# Altium schematic sheet PIN(5~6).SchDoc(PIN(5~6))
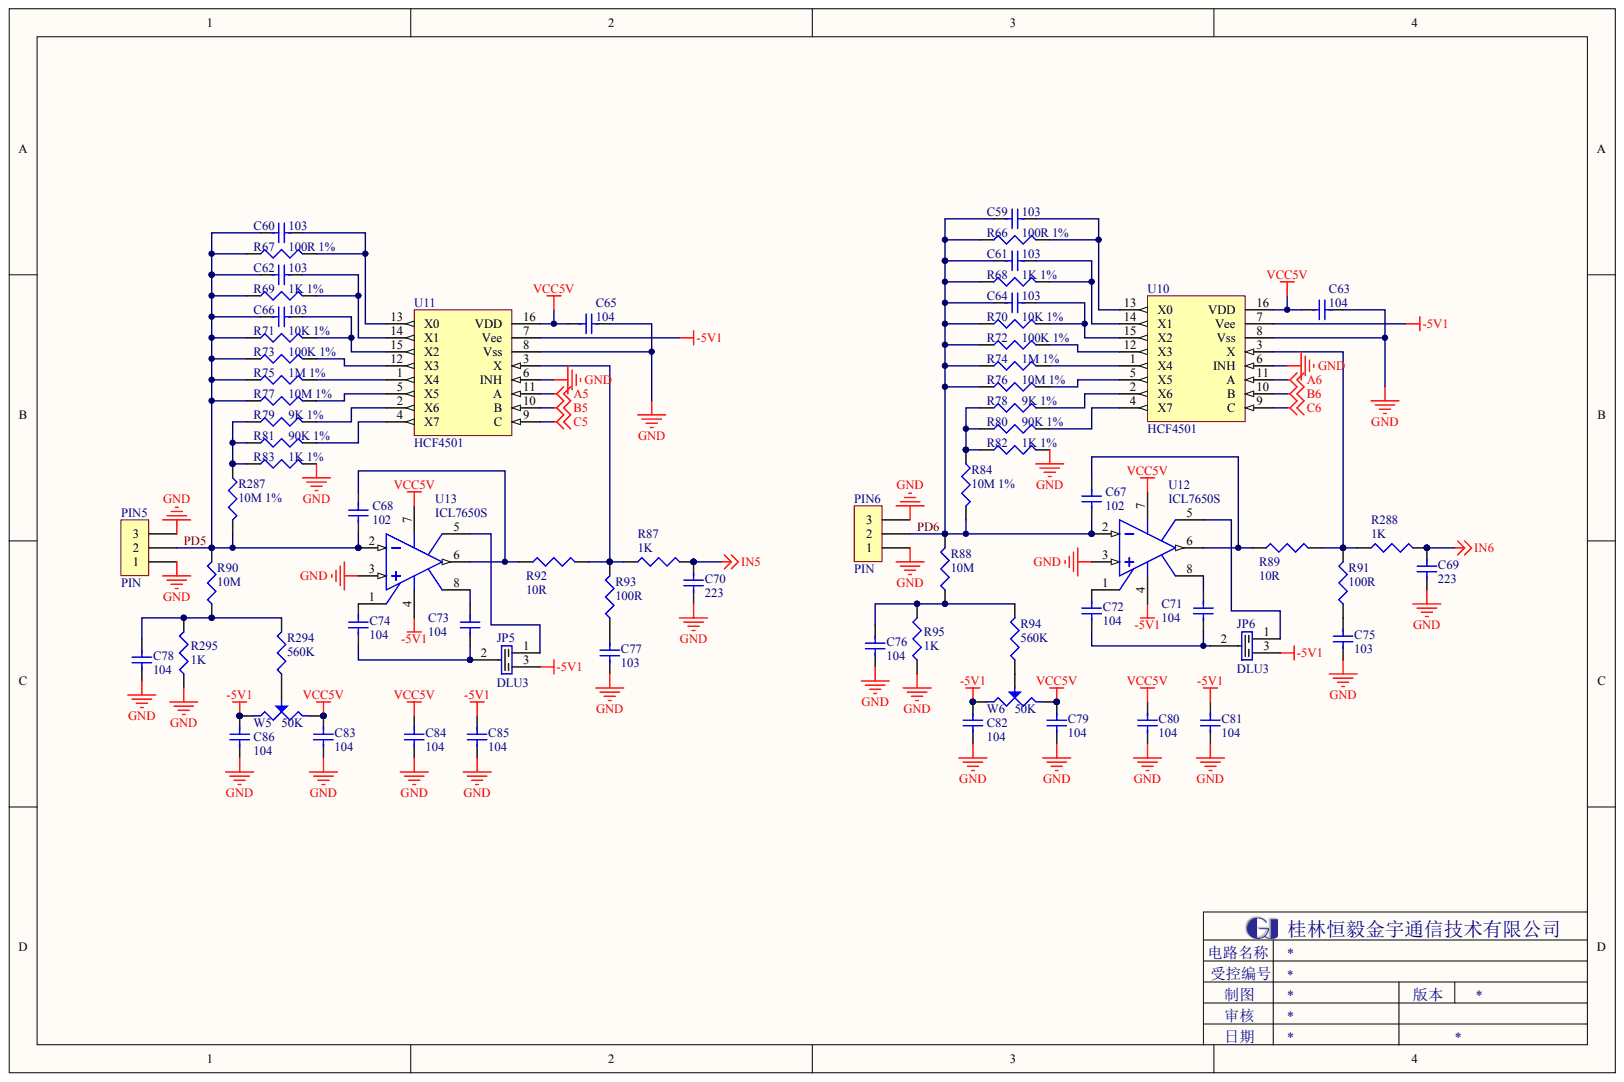
[diagram p0: PIN]

## Parts
C59  103
C60  103
C61  103
C62  103
C63  104
C64  103
C65  104
C66  103
C67  102
C68  102
C69  223
C70  223
C71  104
C72  104
C73  104
C74  104
C75  103
C76  104
C77  103
C78  104
C79  104
C80  104
C81  104
C82  104
C83  104
C84  104
C85  104
C86  104
JP5  DLU3
JP6  DLU3
PIN5  PIN
PIN6  PIN
R66  100R 1%
R67  100R 1%
R68  1K 1%
R69  1K 1%
R70  10K 1%
R71  10K 1%
R72  100K 1%
R73  100K 1%
R74  1M 1%
R75  1M 1%
R76  10M 1%
R77  10M 1%
R78  9K 1%
R79  9K 1%
R80  90K 1%
R81  90K 1%
R82  1K 1%
R83  1K 1%
R84  10M 1%
R87  1K
R88  10M
R89  10R
R90  10M
R91  100R
R92  10R
R93  100R
R94  560K
R95  1K
R287  10M 1%
R288  1K
R294  560K
R295  1K
U10  HCF4501
U11  HCF4501
U12  ICL7650S
U13  ICL7650S
W5  50K
W6  50K

## Nets
-5V1: C81.2 C82.1 C85.2 C86.1 JP5.3 JP6.3 U10.7(Vee) U11.7(Vee) U12.4 U13.4 W5.1 W6.1
A5: U11.11(A)
A6: U10.11(A)
B5: U11.10(B)
B6: U10.10(B)
C5: U11.9(C)
C6: U10.9(C)
GND: C63.2 C65.2 C69.1 C70.1 C75.1 C76.1 C77.1 C78.1 C79.1 C80.1 C81.1 C82.2 C83.1 C84.1 C85.1 C86.2 PIN5.1 PIN5.3 PIN6.1 PIN6.3 R82.2 R83.2 R95.1 R295.1 U10.6(INH) U10.8(Vss) U11.6(INH) U11.8(Vss) U12.3 U13.3
IN5: C70.2 R87.2
IN6: C69.2 R288.2
VCC5V: C63.1 C65.1 C79.2 C80.2 C83.2 C84.2 U10.16(VDD) U11.16(VDD) U12.7 U13.7 W5.3 W6.3
PD5: C60.1 C62.1 C66.1 C68.1 PIN5.2 R67.1 R69.1 R71.1 R73.1 R75.1 R77.1 R90.2 R287.2 U13.2
PD6: C59.1 C61.1 C64.1 C67.1 PIN6.2 R66.1 R68.1 R70.1 R72.1 R74.1 R76.1 R84.2 R88.2 U12.2
NetC59_2: C59.2 R66.2 U10.13(X0)
NetC60_2: C60.2 R67.2 U11.13(X0)
NetC61_2: C61.2 R68.2 U10.14(X1)
NetC62_2: C62.2 R69.2 U11.14(X1)
NetC64_2: C64.2 R70.2 U10.15(X2)
NetC66_2: C66.2 R71.2 U11.15(X2)
NetC67_2: C67.2 R89.1 U12.6
NetC68_2: C68.2 R92.1 U13.6
NetC71_1: C71.1 U12.8
NetC71_2: C71.2 C72.1 JP6.2
NetC72_2: C72.2 U12.1
NetC73_1: C73.1 U13.8
NetC73_2: C73.2 C74.1 JP5.2
NetC74_2: C74.2 U13.1
NetC75_2: C75.2 R91.1
NetC76_2: C76.2 R88.1 R94.2 R95.2
NetC77_2: C77.2 R93.1
NetC78_2: C78.2 R90.1 R294.2 R295.2
NetJP5_1: JP5.1 U13.5
NetJP6_1: JP6.1 U12.5
NetR72_2: R72.2 U10.12(X3)
NetR73_2: R73.2 U11.12(X3)
NetR74_2: R74.2 U10.1(X4)
NetR75_2: R75.2 U11.1(X4)
NetR76_2: R76.2 U10.5(X5)
NetR77_2: R77.2 U11.5(X5)
NetR78_1: R78.1 R80.1 R82.1 R84.1
NetR78_2: R78.2 U10.2(X6)
NetR79_1: R79.1 R81.1 R83.1 R287.1
NetR79_2: R79.2 U11.2(X6)
NetR80_2: R80.2 U10.4(X7)
NetR81_2: R81.2 U11.4(X7)
NetR87_1: R87.1 R92.2 R93.2 U11.3(X)
NetR89_2: R89.2 R91.2 R288.1 U10.3(X)
NetR94_1: R94.1 W6.2
NetR294_1: R294.1 W5.2
